# Altium schematic sheet CM4_Conn.SchDoc(CM4_Conn)
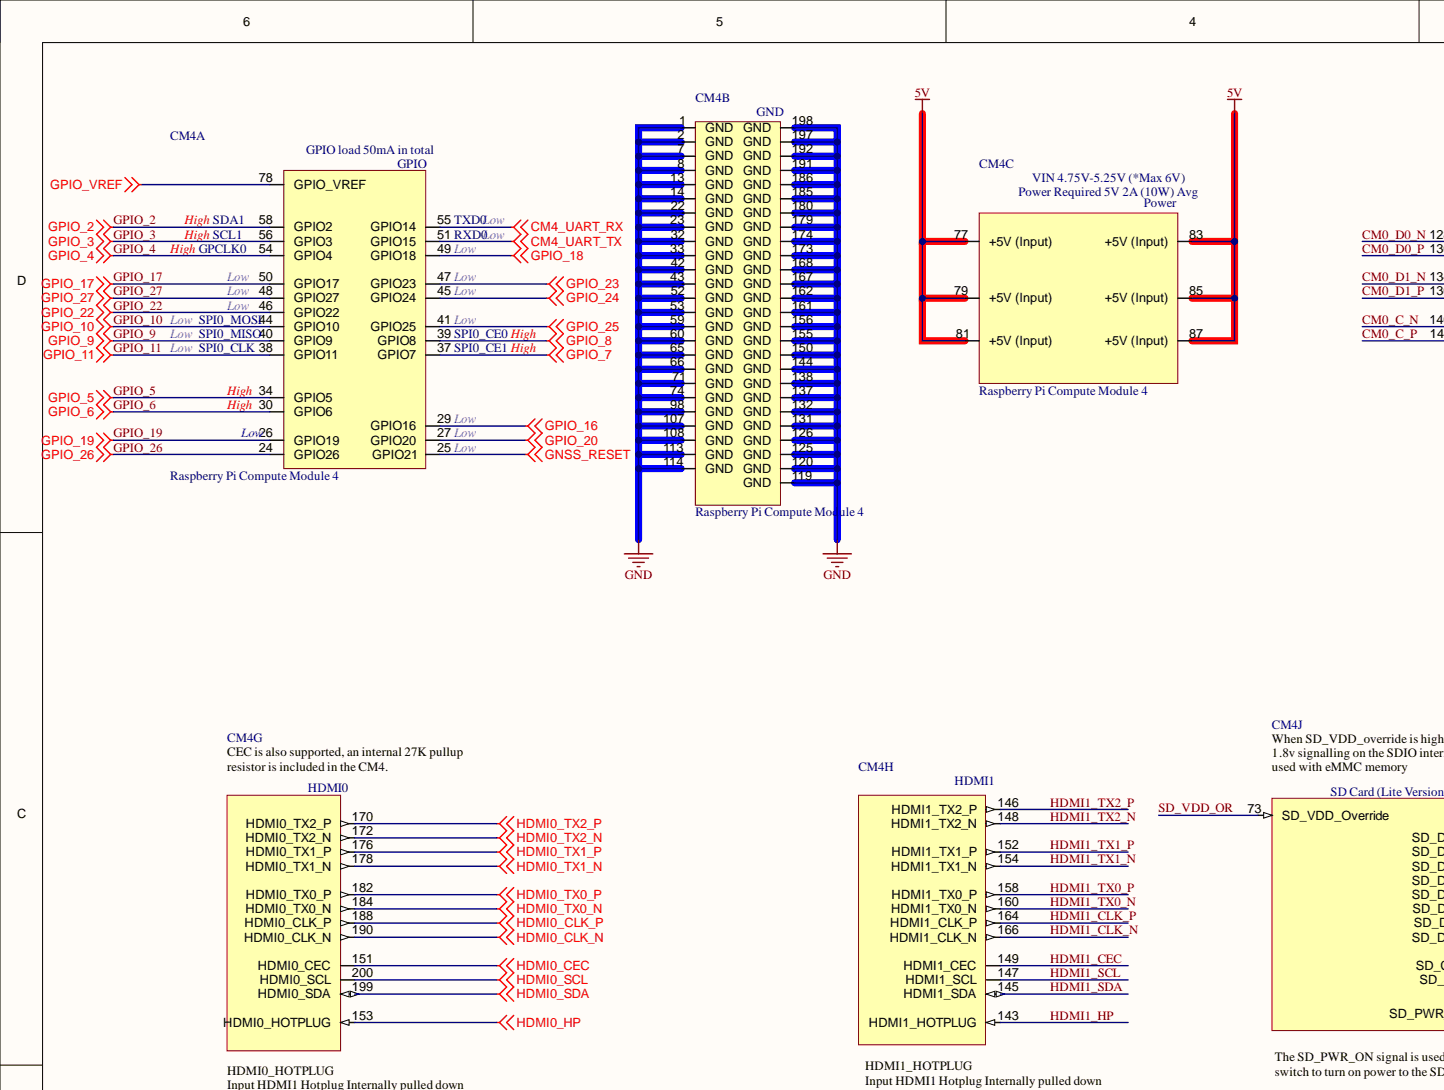
[diagram p0: CM4_Conn.SchDoc, top left]
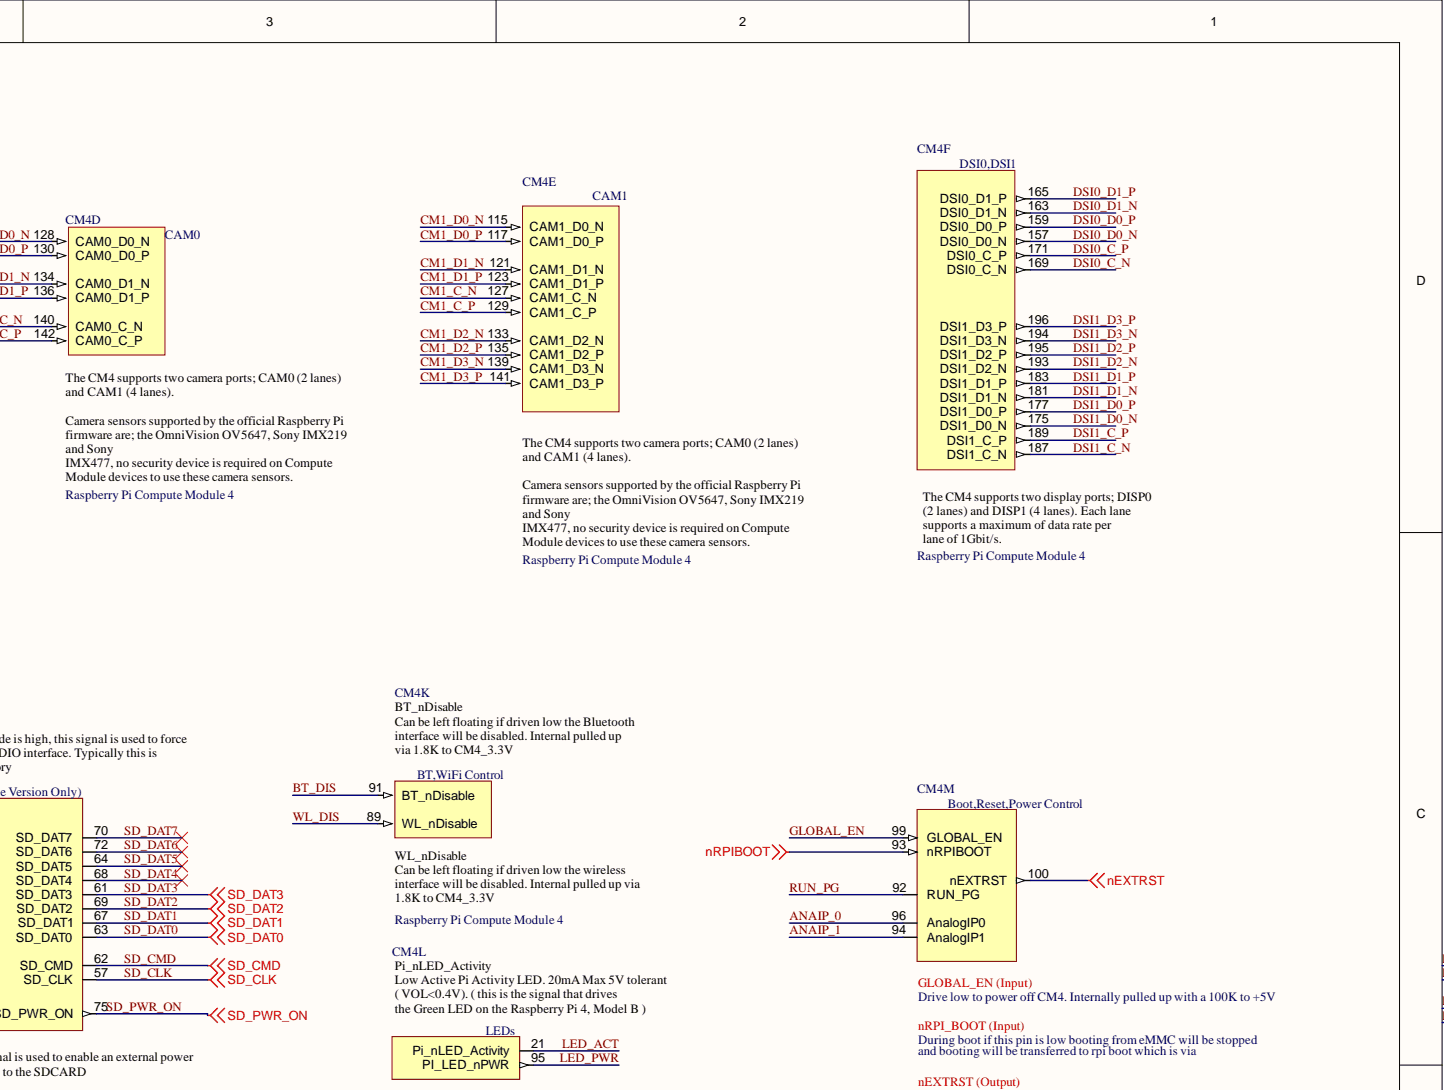
[diagram p1: CM4_Conn.SchDoc, top right]
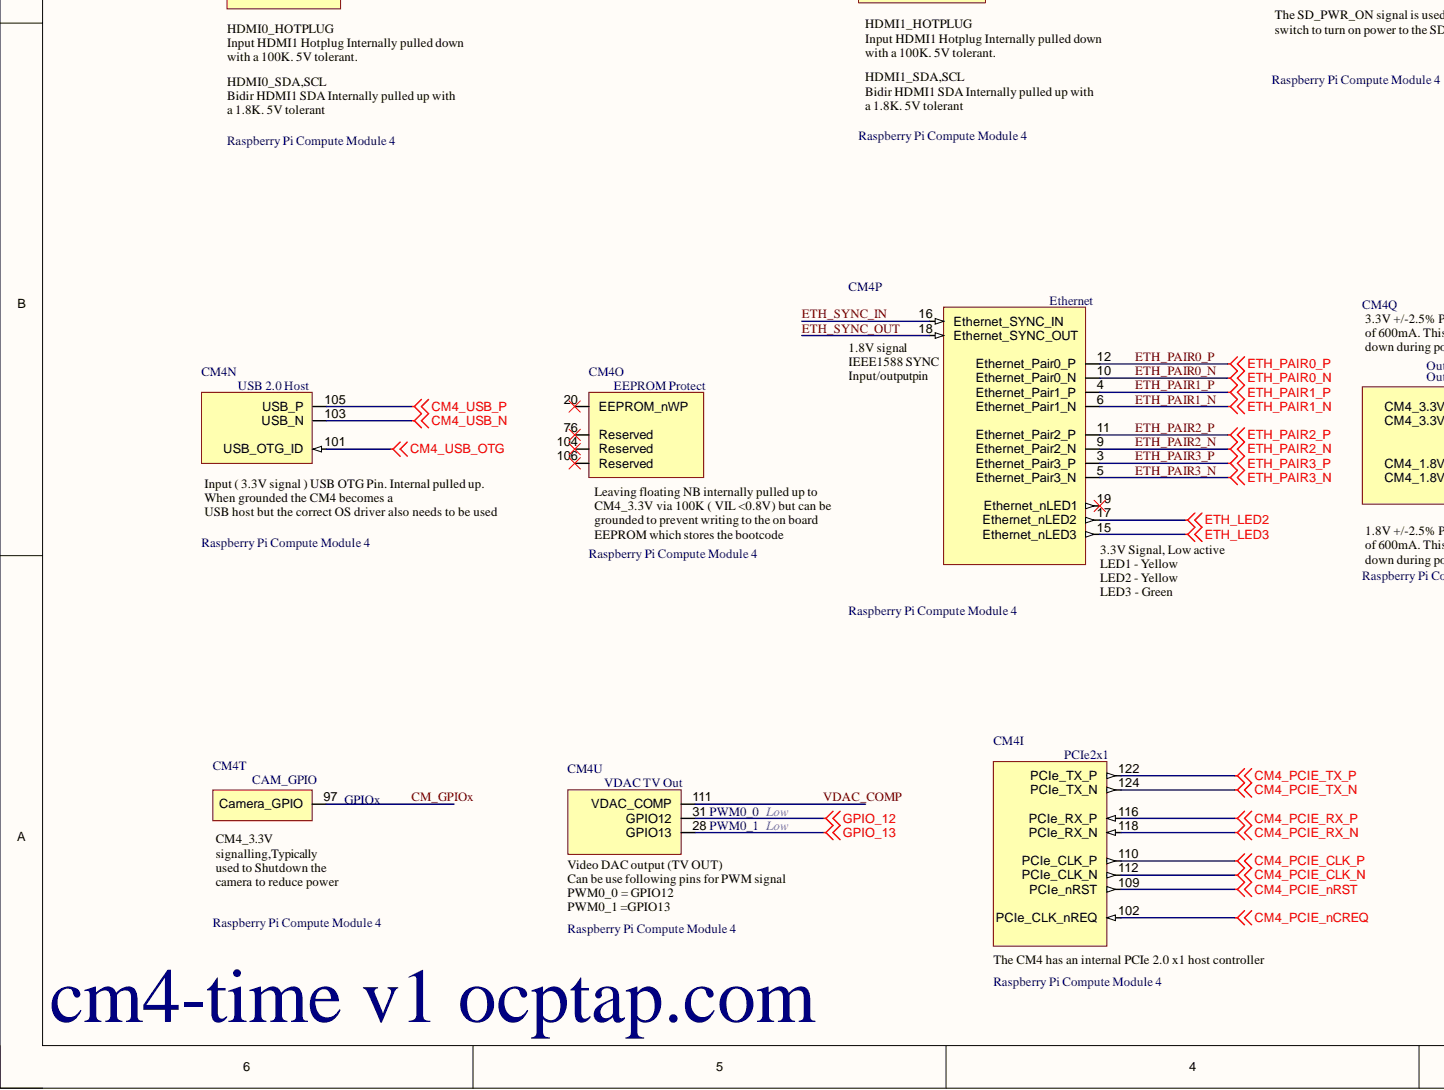
[diagram p2: CM4_Conn.SchDoc, bottom left]
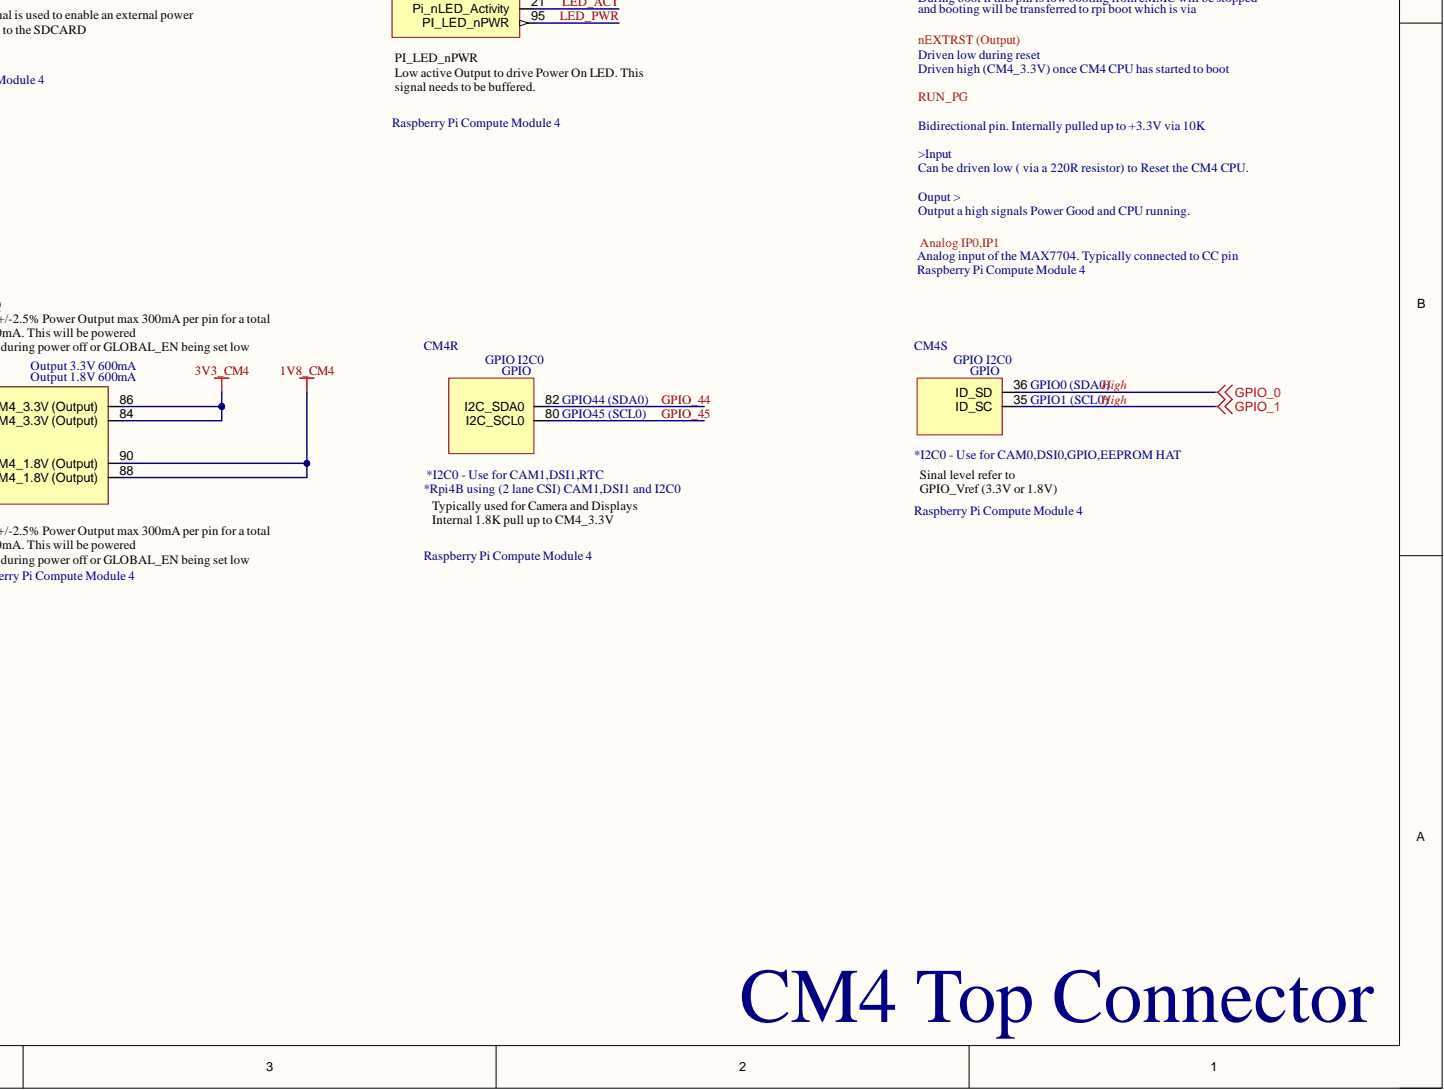
[diagram p3: CM4_Conn.SchDoc, bottom right]

## Parts
CM4  Raspberry Pi Compute Module 4  [CM4-F40C-100DS-0.4v_1.5mm_0mm]  (no BOM)  (parts A,B,C,D,E,F,G,H,I,J,K,L,M,N,O,P,Q,R,S,T,U)
J7  DF40HC_3.0_-100DS-0.4V_51  [DF40HC30100DS04V51]  (value not drawn)
J8  DF40HC_3.0_-100DS-0.4V_51  [DF40HC30100DS04V51]  (value not drawn)

## Nets
1V8_CM4: CM4.88 CM4.90
3V3_CM4: CM4.84 CM4.86
5V: CM4.77(+5V_(Input)) CM4.79(+5V_(Input)) CM4.81(+5V_(Input)) CM4.83(+5V_(Input)) CM4.85(+5V_(Input)) CM4.87(+5V_(Input))
CM4_PCIE_CLK_N: CM4.112
CM4_PCIE_CLK_P: CM4.110
CM4_PCIE_nCREQ: CM4.102
CM4_PCIE_nRST: CM4.109
CM4_PCIE_RX_N: CM4.118
CM4_PCIE_RX_P: CM4.116
CM4_PCIE_TX_N: CM4.124
CM4_PCIE_TX_P: CM4.122
CM4_UART_RX: CM4.55(GPIO14)
CM4_UART_TX: CM4.51(GPIO15)
CM4_USB_N: CM4.103
CM4_USB_OTG: CM4.101
CM4_USB_P: CM4.105
ETH_LED2: CM4.17(Ethernet_nLED2)
ETH_LED3: CM4.15(Ethernet_nLED3)
GND: CM4.1(GND) CM4.2(GND) CM4.7(GND) CM4.8(GND) CM4.13(GND) CM4.14(GND) CM4.22(GND) CM4.23(GND) CM4.32(GND) CM4.33(GND) CM4.42(GND) CM4.43(GND) CM4.52(GND) CM4.53(GND) CM4.59(GND) CM4.60(GND) CM4.65(GND) CM4.66(GND) CM4.71(GND) CM4.74(GND) CM4.98(GND) CM4.107(GND) CM4.108(GND) CM4.113(GND) CM4.114(GND) CM4.119(GND) CM4.120(GND) CM4.125(GND) CM4.126(GND) CM4.131(GND) CM4.132(GND) CM4.137(GND) CM4.138(GND) CM4.144(GND) CM4.150(GND) CM4.155(GND) CM4.156(GND) CM4.161(GND) CM4.162(GND) CM4.167(GND) CM4.168(GND) CM4.173(GND) CM4.174(GND) CM4.179(GND) CM4.180(GND) CM4.185(GND) CM4.186(GND) CM4.191(GND) CM4.192(GND) CM4.197(GND) CM4.198(GND) J7.1 J7.2 J7.7 J7.8 J7.13 J7.14 J7.22 J7.23 J7.32 J7.33 J7.42 J7.43 J7.52 J7.53 J7.59 J7.60 J7.65 J7.66 J7.71 J7.74 J7.98 J8.7 J8.8 J8.13 J8.14 J8.19 J8.20 J8.25 J8.26 J8.31 J8.32 J8.37 J8.38 J8.44 J8.50 J8.55 J8.56 J8.61 J8.62 J8.67 J8.68 J8.73 J8.74 J8.79 J8.80 J8.85 J8.86 J8.91 J8.92 J8.97 J8.98
GNSS_RESET: CM4.25(Phunsin)
GPIO_0: CM4.36
GPIO_1: CM4.35
GPIO_7: CM4.37(GPIO7)
GPIO_8: CM4.39(GPIO8)
GPIO_12: CM4.31(GPIO12)
GPIO_13: CM4.28(GPIO13)
GPIO_16: CM4.29(GPIO16)
GPIO_18: CM4.49(GPIO18)
GPIO_20: CM4.27(GPIO20)
GPIO_23: CM4.47(GPIO23)
GPIO_24: CM4.45(GPIO24)
GPIO_25: CM4.41(GPIO25)
GPIO_VREF: CM4.78(GPIO_VREF)
HDMI0_CEC: CM4.151(HDMI0_CEC)
HDMI0_CLK_N: CM4.190(HDMI0_CLK_N)
HDMI0_CLK_P: CM4.188(HDMI0_CLK_P)
HDMI0_HP: CM4.153
HDMI0_SCL: CM4.200(HDMI0_SCL)
HDMI0_SDA: CM4.199(HDMI0_SDA)
HDMI0_TX0_N: CM4.184(HDMI0_TX0_N)
HDMI0_TX0_P: CM4.182(HDMI0_TX0_P)
HDMI0_TX1_N: CM4.178(HDMI0_TX1_N)
HDMI0_TX1_P: CM4.176(HDMI0_TX1_P)
HDMI0_TX2_N: CM4.172(HDMI0_TX2_N)
HDMI0_TX2_P: CM4.170(HDMI0_TX2_P)
nEXTRST: CM4.100
nRPIBOOT: CM4.93(nRPIBOOT)
ANAIP_0: CM4.96(AnalogIP0)
ANAIP_1: CM4.94(AnalogIP1)
BT_DIS: CM4.91(BT_nDisable)
CM0_C_N: CM4.140(CAM0_C_N)
CM0_C_P: CM4.142(CAM0_C_P)
CM0_D0_N: CM4.128(CAM0_D0_N)
CM0_D0_P: CM4.130(CAM0_D0_P)
CM0_D1_N: CM4.134(CAM0_D1_N)
CM0_D1_P: CM4.136(CAM0_D1_P)
CM1_C_N: CM4.127(CAM1_C_N)
CM1_C_P: CM4.129(CAM1_C_P)
CM1_D0_N: CM4.115(CAM1_D0_N)
CM1_D0_P: CM4.117(CAM1_D0_P)
CM1_D1_N: CM4.121(CAM1_D1_N)
CM1_D1_P: CM4.123(CAM1_D1_P)
CM1_D2_N: CM4.133(CAM1_D2_N)
CM1_D2_P: CM4.135(CAM1_D2_P)
CM1_D3_N: CM4.139(CAM1_D3_N)
CM1_D3_P: CM4.141(CAM1_D3_P)
CM_GPIOx: CM4.97(Camera_GPIO)
DSI0_C_N: CM4.169
DSI0_C_P: CM4.171
DSI0_D0_N: CM4.157
DSI0_D0_P: CM4.159
DSI0_D1_N: CM4.163
DSI0_D1_P: CM4.165
DSI1_C_N: CM4.187
DSI1_C_P: CM4.189
DSI1_D0_N: CM4.175
DSI1_D0_P: CM4.177
DSI1_D1_N: CM4.181
DSI1_D1_P: CM4.183
DSI1_D2_N: CM4.193
DSI1_D2_P: CM4.195
DSI1_D3_N: CM4.194
DSI1_D3_P: CM4.196
ETH_PAIR0_N: CM4.10(Ethernet_Pair0_N) J7.10
ETH_PAIR0_P: CM4.12(Ethernet_Pair0_P) J7.12
ETH_PAIR1_N: CM4.6(Ethernet_Pair1_N) J7.6
ETH_PAIR1_P: CM4.4(Ethernet_Pair1_P) J7.4
ETH_PAIR2_N: CM4.9(Ethernet_Pair2_N) J7.9
ETH_PAIR2_P: CM4.11(Ethernet_Pair2_P) J7.11
ETH_PAIR3_N: CM4.5(Ethernet_Pair3_N) J7.5
ETH_PAIR3_P: CM4.3(Ethernet_Pair3_P) J7.3
ETH_SYNC_IN: CM4.16(Ethernet_SYNC_IN)
ETH_SYNC_OUT: CM4.18(Ethernet_SYNC_OUT)
GLOBAL_EN: CM4.99(GLOBAL_EN)
GPIO_2: CM4.58(GPIO2)
GPIO_3: CM4.56(GPIO3)
GPIO_4: CM4.54(GPIO4)
GPIO_5: CM4.34(GPIO5)
GPIO_6: CM4.30(GPIO6)
GPIO_9: CM4.40(GPIO9)
GPIO_10: CM4.44(GPIO10)
GPIO_11: CM4.38(GPIO11)
GPIO_17: CM4.50(GPIO17)
GPIO_19: CM4.26(GPIO19)
GPIO_22: CM4.46(GPIO22)
GPIO_26: CM4.24(GPIO26)
GPIO_27: CM4.48(GPIO27)
GPIO_44: CM4.82
GPIO_45: CM4.80(GPIO45_(SCL0))
HDMI1_CEC: CM4.149(HDMI1_CEC)
HDMI1_CLK_N: CM4.166(HDMI1_CLK_N)
HDMI1_CLK_P: CM4.164(HDMI1_CLK_P)
HDMI1_HP: CM4.143(HDMI1_HOTPLUG)
HDMI1_SCL: CM4.147(HDMI1_SCL)
HDMI1_SDA: CM4.145(HDMI1_SDA)
HDMI1_TX0_N: CM4.160(HDMI1_TX0_N)
HDMI1_TX0_P: CM4.158(HDMI1_TX0_P)
HDMI1_TX1_N: CM4.154(HDMI1_TX1_N)
HDMI1_TX1_P: CM4.152(HDMI1_TX1_P)
HDMI1_TX2_N: CM4.148(HDMI1_TX2_N)
HDMI1_TX2_P: CM4.146(HDMI1_TX2_P)
LED_ACT: CM4.21
LED_PWR: CM4.95
RUN_PG: CM4.92(RUN_PG)
SD_CLK: CM4.57(SD_CLK)
SD_CMD: CM4.62(SD_CMD)
SD_DAT0: CM4.63(SD_DAT0)
SD_DAT1: CM4.67(SD_DAT1)
SD_DAT2: CM4.69(SD_DAT2)
SD_DAT3: CM4.61(SD_DAT3)
SD_DAT4: CM4.68(SD_DAT4)
SD_DAT5: CM4.64(SD_DAT5)
SD_DAT6: CM4.72(SD_DAT6)
SD_DAT7: CM4.70(SD_DAT7)
SD_PWR_ON: CM4.75(SD_PWR_ON)
SD_VDD_OR: CM4.73(SD_VDD_Override)
VDAC_COMP: CM4.111(VDAC_COMP)
WL_DIS: CM4.89(WL_nDisable)

Not connected: CM4 19(Ethernet_nLED1) 20(EEPROM_nWP) 76(Reserved) 104(Reserved) 106(Reserved); J7 15 16 17 18 19 20 21 24 25 26 27 28 29 30 31 34 35 36 37 38 39 40 41 44 45 46 47 48 49 50 51 54 55 56 57 58 61 62 63 64 67 68 69 70 72 73 75 76 77 78 79 80 81 82 83 84 85 86 87 88 89 90 91 92 93 94 95 96 97 99 100; J8 1 2 3 4 5 6 9 10 11 12 15 16 17 18 21 22 23 24 27 28 29 30 33 34 35 36 39 40 41 42 43 45 46 47 48 49 51 52 53 54 57 58 59 60 63 64 65 66 69 70 71 72 75 76 77 78 81 82 83 84 87 88 89 90 93 94 95 96 99 100

## Library data of the parts
CM4 = CM4: Raspberry Pi Compute Module 4 by Amornthep Phunsin; Library Name: CM4.SchLib
J7 J8 = DF40HC_3.0_-100DS-0.4V_51: Connector; Manufacturer_Name: Hirose; Manufacturer_Part_Number: DF40HC(3.0)-100DS-0.4V(51; Arrow Part Number: DF40HC(3.0)-100DS-0.4V(51; Arrow Price/Stock: https://www.arrow.com/en/products/df40hc-3.0-100ds-0.4v-51/hirose-electric; Component Kind: Standard; Datasheet Link: https://componentsearchengine.com/Datasheets/1/DF40HC(3.0)-100DS-0.4V(51.pdf; Height: 3.05mm; Library Name: SamacSys.SchLib; Mouser Part Number: 798-DF40HC30100DS451; Mouser Price/Stock: https://www.mouser.co.uk/ProductDetail/Hirose-Connector/DF40HC3.0-100DS-0.4V51?qs=%252BAZVS4b%2FkgIV%252B3vHYy2dCA%3D%3D
